# Altium schematic sheet Display.SchDoc
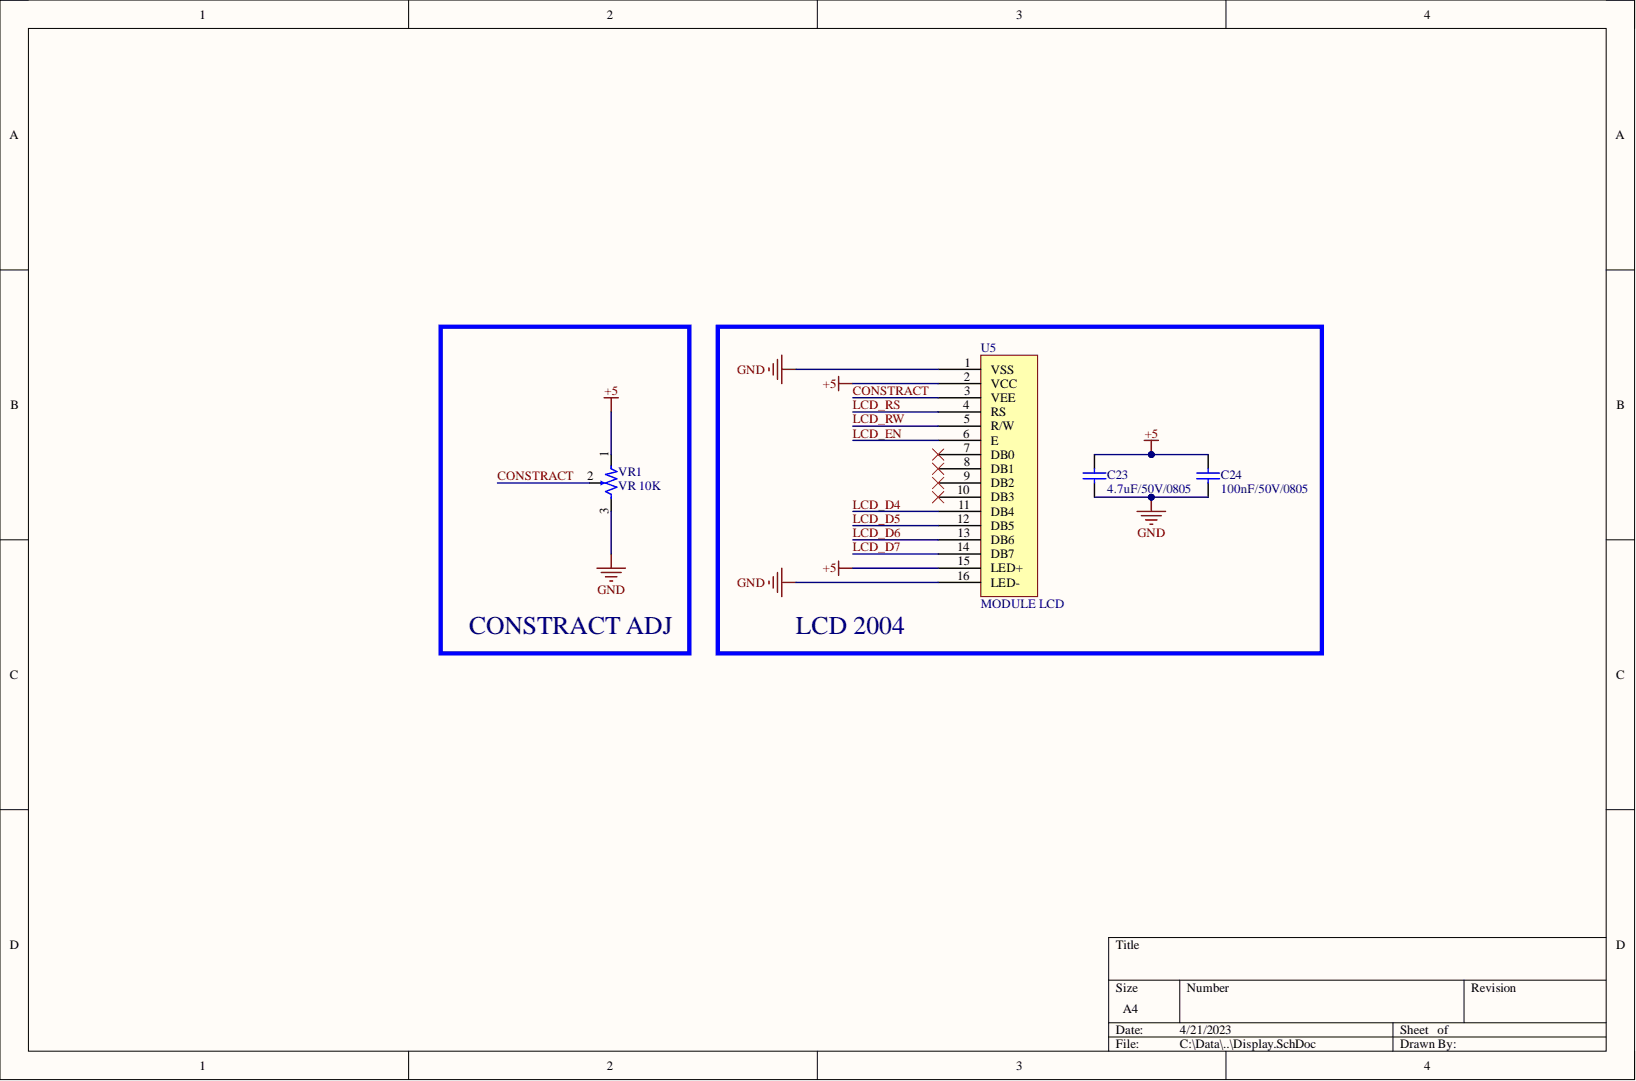
[diagram p0: Display.SchDoc]

## Parts
C23  value 4.7uF/50V/0805  [CAP-SM-0805]  (hidden comment: Cap Non-Pol SMD)
C24  value 100nF/50V/0805  [CAP-SM-0805]  (hidden comment: Cap Non-Pol SMD)
U5  MODULE LCD  [MODULE LCD 2004]
VR1  10K  value VR 10K  [VARISTOR 2]

## Nets
+5: C23.1 C24.1 U5.2(VCC) U5.15(LED+) VR1.1
GND: C23.2 C24.2 U5.1(VSS) U5.16(LED-) VR1.3
CONSTRACT: U5.3(VEE) VR1.2
LCD_D4: U5.11(DB4)
LCD_D5: U5.12(DB5)
LCD_D6: U5.13(DB6)
LCD_D7: U5.14(DB7)
LCD_EN: U5.6(E)
LCD_RS: U5.4(RS)
LCD_RW: U5.5(R/W)

Not connected: U5 7(DB0) 8(DB1) 9(DB2) 10(DB3)

## Library data of the parts
C23 C24 = Cap Non-Pol SMD: Capacitor; Component Kind: Standard; Library Name: PIF_Library.IntLib
VR1 = Var Resistor: Component Kind: Standard; Description: Var Resistor; Library Name: PIF_Library.IntLib
U5 = MODULE LCD: Component Kind: Standard; Library Name: Giang's Libs.IntLib
